# Altium schematic sheet VT6212 Chipset.SchDoc
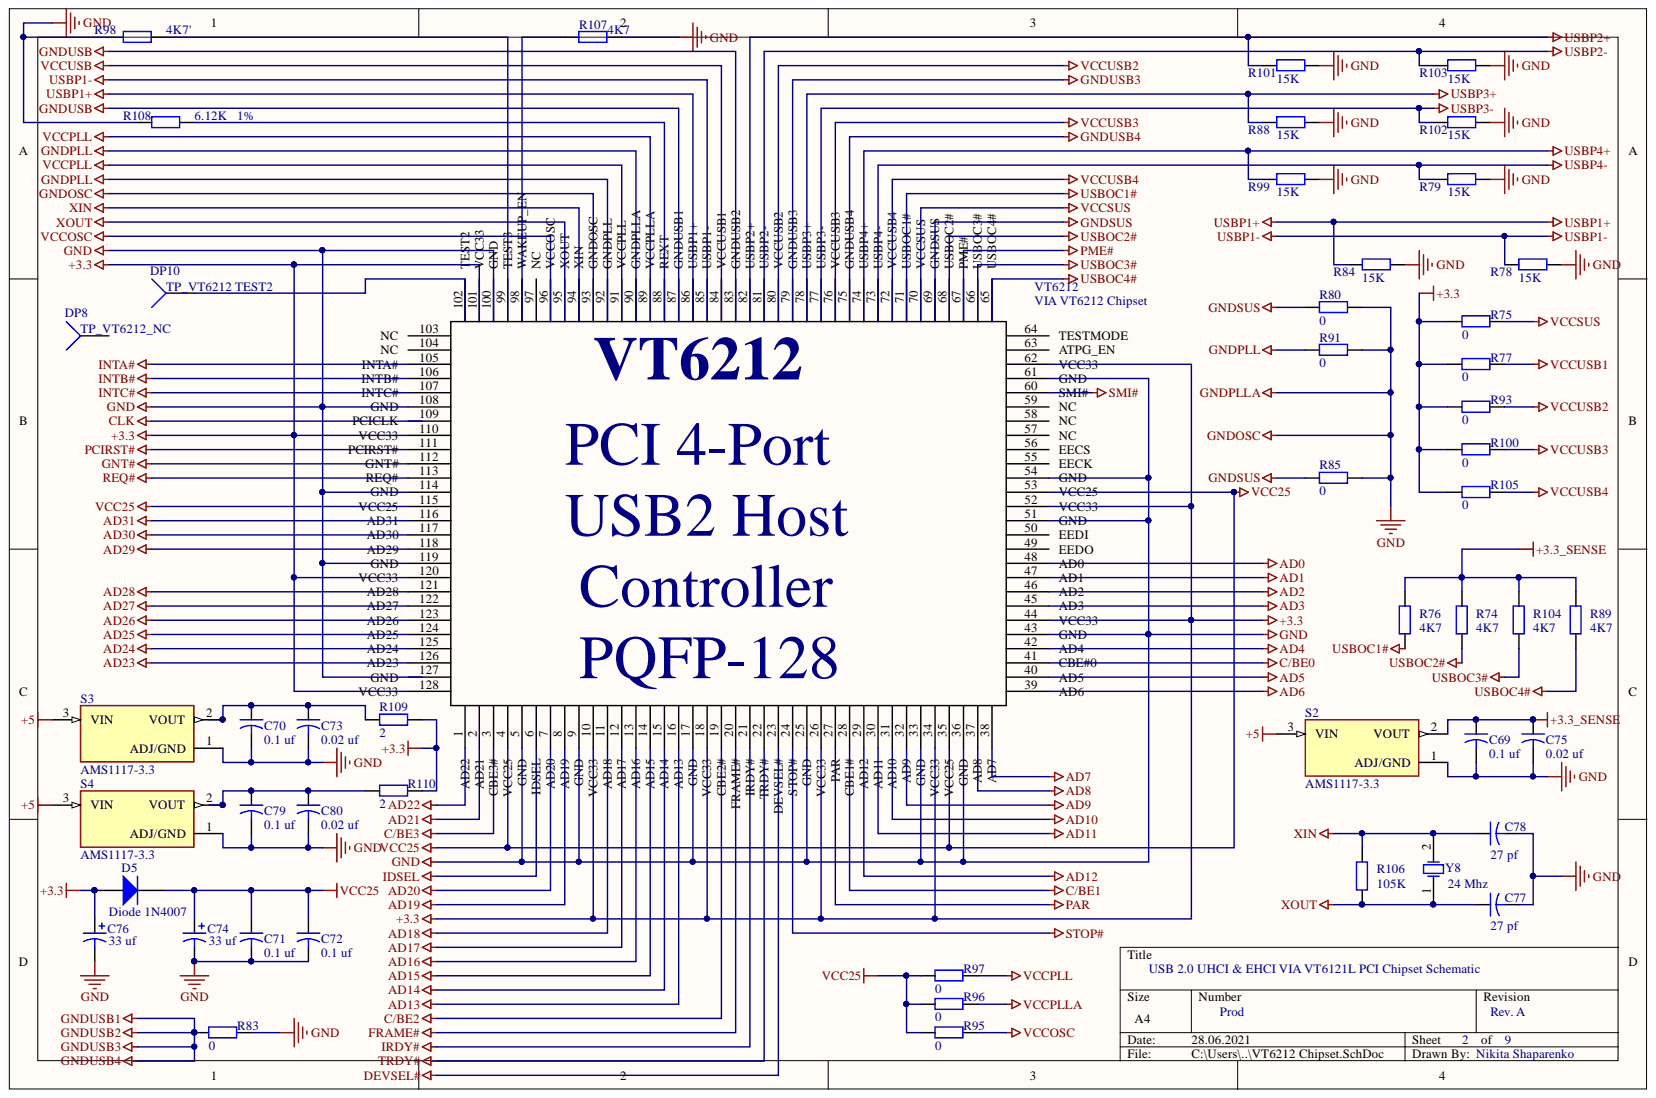
[diagram p0: VT6212 Chipset.SchDoc]

## Parts
C69  value 0.1 uf  [CAPR5-4X5]  (hidden comment: Cap2)
C70  value 0.1 uf  [CAPR5-4X5]  (hidden comment: Cap2)
C71  value 0.1 uf  [CAPR5-4X5]  (hidden comment: Cap2)
C72  value 0.1 uf  [CAPR5-4X5]  (hidden comment: Cap2)
C73  value 0.02 uf  [CAPR5-4X5]  (hidden comment: Cap2)
C74  value 33 uf  [RAD-0.2]  (hidden comment: Cap Pol1)
C75  value 0.02 uf  [CAPR5-4X5]  (hidden comment: Cap2)
C76  value 33 uf  [RAD-0.2]  (hidden comment: Cap Pol1)
C77  value 27 pf  [CAPR5-4X5]  (hidden comment: Cap2)
C78  value 27 pf  [CAPR5-4X5]  (hidden comment: Cap2)
C79  value 0.1 uf  [CAPR5-4X5]  (hidden comment: Cap2)
C80  value 0.02 uf  [CAPR5-4X5]  (hidden comment: Cap2)
D5  Diode 1N4007  [DO-41]
DP8  TP_VT6212_NC  [PIN1]
DP10  TP_VT6212 TEST2  [PIN1]
R74  value 4K7  [AXIAL-0.4]  (hidden comment: Res2)
R75  value 0  [AXIAL-0.4]  (hidden comment: Res2)
R76  value 4K7  [AXIAL-0.4]  (hidden comment: Res2)
R77  value 0  [AXIAL-0.4]  (hidden comment: Res2)
R78  value 15K  [AXIAL-0.4]  (hidden comment: Res2)
R79  value 15K  [AXIAL-0.4]  (hidden comment: Res2)
R80  value 0  [AXIAL-0.4]  (hidden comment: Res2)
R83  value 0  [AXIAL-0.4]  (hidden comment: Res2)
R84  value 15K  [AXIAL-0.4]  (hidden comment: Res2)
R85  value 0  [AXIAL-0.4]  (hidden comment: Res2)
R88  value 15K  [AXIAL-0.4]  (hidden comment: Res2)
R89  value 4K7  [AXIAL-0.4]  (hidden comment: Res2)
R91  value 0  [AXIAL-0.4]  (hidden comment: Res2)
R93  value 0  [AXIAL-0.4]  (hidden comment: Res2)
R95  value 0  [AXIAL-0.4]  (hidden comment: Res2)
R96  value 0  [AXIAL-0.4]  (hidden comment: Res2)
R97  value 0  [AXIAL-0.4]  (hidden comment: Res2)
R98  value 4K7'  [AXIAL-0.4]
R99  value 15K  [AXIAL-0.4]  (hidden comment: Res2)
R100  value 0  [AXIAL-0.4]  (hidden comment: Res2)
R101  value 15K  [AXIAL-0.4]  (hidden comment: Res2)
R102  value 15K  [AXIAL-0.4]  (hidden comment: Res2)
R103  value 15K  [AXIAL-0.4]  (hidden comment: Res2)
R104  value 4K7  [AXIAL-0.4]  (hidden comment: Res2)
R105  value 0  [AXIAL-0.4]  (hidden comment: Res2)
R106  value 105K  [AXIAL-0.4]  (hidden comment: Res2)
R107  value 4K7  [AXIAL-0.4]  (hidden comment: Res2)
R108  1%  value 6.12K  [AXIAL-0.4]
R109  value 2  [AXIAL-0.4]  (hidden comment: Res2)
R110  value 2  [AXIAL-0.4]  (hidden comment: Res2)
S2  AMS1117-3.3  [SOT229P700X180-4N]
S3  AMS1117-3.3  [SOT229P700X180-4N]
S4  AMS1117-3.3  [SOT229P700X180-4N]
VT6212  VIA VT6212 Chipset  [NXP LQFP-144 SOT486-1]
Y8  24 Mhz  [R38]

## Nets
+3.3: C76.1 D5.1 R75.2 R77.2 R93.2 R100.2 R105.2 R109.2 R110.1 VT6212.101
+3.3_SENSE: C69.1 C75.1 R74.2 R76.2 R89.2 R104.2 S2.2
+5: S2.3 S3.3 S4.3
GND: C69.2 C70.2 C71.2 C72.2 C73.2 C74.2 C75.2 C76.2 C77.2 C78.2 C79.2 C80.2 R78.1 R79.1 R80.2 R83.1 R84.1 R85.2 R88.1 R91.2 R98.1 R99.1 R101.1 R102.1 R103.1 R107.1 R108.1 S2.1 S3.1 S4.1 VT6212.93 VT6212.100
GNDPLL: R91.1 VT6212.90 VT6212.92
GNDSUS: R80.1 R85.1 VT6212.69
GNDUSB: VT6212.83 VT6212.87
GNDUSB1: R83.2 VT6212.75 VT6212.79
PME#: VT6212.67
USBOC1#: R76.1 VT6212.71
USBOC2#: R74.1 VT6212.68
USBOC3#: R104.1 VT6212.66
USBOC4#: R89.1 VT6212.65
USBP1+: R84.2 VT6212.86
USBP1-: R78.2 VT6212.85
USBP2+: R101.2 VT6212.82
USBP2-: R103.2 VT6212.81
USBP3+: R88.2 VT6212.78
USBP3-: R102.2 VT6212.77
USBP4+: R99.2 VT6212.74
USBP4-: R79.2 VT6212.73
VCC25: C71.1 C72.1 C74.1 D5.2 R95.2 R96.2 R97.2
VCCOSC: R95.1 VT6212.96
VCCPLL: R97.1 VT6212.89 VT6212.91
VCCPLLA: R96.1
VCCSUS: R75.1 VT6212.70
VCCUSB: VT6212.84
VCCUSB1: R77.1
VCCUSB2: R93.1 VT6212.80
VCCUSB3: R100.1 VT6212.76
VCCUSB4: R105.1 VT6212.72
XIN: C78.1 R106.2 VT6212.94 Y8.2
XOUT: C77.1 R106.1 VT6212.95 Y8.1
NetC70_1: C70.1 C73.1 R109.1 S3.2
NetC79_1: C79.1 C80.1 R110.2 S4.2
NetDP10_1: DP10.1 VT6212.102
NetR98_2: R98.2 VT6212.99
NetR107_2: R107.2 VT6212.98
NetR108_2: R108.2 VT6212.88
AD0:
AD1:
AD2:
AD3:
AD4:
AD5:
AD6:
AD7:
AD8:
AD9:
AD10:
AD11:
AD12:
AD13:
AD14:
AD15:
AD16:
AD17:
AD18:
AD19:
AD20:
AD21:
AD22:
AD23:
AD24:
AD25:
AD26:
AD27:
AD28:
AD29:
AD30:
AD31:
C/BE0:
C/BE1:
C/BE2:
C/BE3:
CLK:
DEVSEL#:
FRAME#:
GNT#:
IDSEL:
INTA#:
INTB#:
INTC#:
IRDY#:
PAR:
PCIRST#:
REQ#:
SMI#:
STOP#:
TRDY#:

Not connected: DP8 1; VT6212 1 2 3 4 5 6 7 8 9 10 11 12 13 14 15 16 17 18 19 20 21 22 23 24 25 26 27 28 29 30 31 32 33 34 35 36 37 38 39 40 41 42 43 44 45 46 47 48 49 50 51 52 53 54 55 56 57 58 59 60 61 62 63 64 97 103 104 105 106 107 108 109 110 111 112 113 114 115 116 117 118 119 120 121 122 123 124 125 126 127 128

## Library data of the parts
C69-C73 C75 C77-C80 = Cap2: Capacitor; Component Kind: Standard; Library Name: Miscellaneous Devices.IntLib; PackageDescription: Capacitor; 2 Leads; PackageReference: CAPR5-4X5; Published: 23-Sep-2002; Signal Integrity: Cap; Simulation: CAP; Publisher: Altium Limited
C74 C76 = Cap Pol1: Polarized Capacitor (Radial); Component Kind: Standard; LatestRevisionDate: 17-Jul-2002; LatestRevisionNote: Re-released for DXP Platform.; Library Name: Miscellaneous Devices.IntLib; PackageDescription: Polarized Capacitor (Radial); 2 Leads; PackageReference: RB7.6-15; Published: 8-Jun-2000; Signal Integrity: Cap Pol; Simulation: CAP; Publisher: Altium Limited
D5 = Diode 1N4007: 1 Amp General Purpose Rectifier; Code_JEDEC: DO-204-AL; Component Kind: Standard; ComponentLink1Description: Manufacturer Link; ComponentLink1URL: http://www.fairchildsemi.com/; ComponentLink2Description: Datasheet; ComponentLink2URL: http://www.fairchildsemi.com/ds/1N%2F1N4001.pdf; DatasheetVersion: 1998; LatestRevisionDate: 17-Jul-2002; LatestRevisionNote: Re-released for DXP Platform.; Library Name: Miscellaneous Devices.IntLib; PackageDescription: Diode, Axial; Body 5.2 x 2.7 mm (LxDia. max), Lead Dia. 0.86 mm (max); PackageReference: DO-41; PackageVersion: Aug-1999; Published: 8-Jun-2000; Signal Integrity: 1N4007; Simulation: 1N4007; Publisher: Altium Limited
DP8 DP10 = Socket: Component Kind: Standard; Description: Socket; LatestRevisionDate: 17-Jul-2002; LatestRevisionNote: Re-released for DXP Platform.; Library Name: Miscellaneous Connectors.IntLib; Signal Integrity: Connector; Publisher: Altium Limited
R74-R80 R83-R85 R88 R89 R91 R93 R95-R110 = Res2: Resistor; Component Kind: Standard; LatestRevisionDate: 17-Jul-2002; LatestRevisionNote: Re-released for DXP Platform.; Library Name: Miscellaneous Devices.IntLib; PackageDescription: Axial Device, Thru-Hole; 2 Leads; 0.4 in Pin Spacing; PackageReference: AXIAL-0.4; Published: 8-Jun-2000; Signal Integrity: Res; Simulation: RESISTOR; Publisher: Altium Limited
S2-S4 = AMS1117-3.3: Sot223/Pkg 1-Amp 3.3-Volt Low Drop Out Voltage Regulatator; MF: Advanced Monolithic Systems; Availability: Unavailable; Component Kind: Standard; Library Name: AMS1117-3.3.IntLib; MP: AMS1117-3.3; Package: None; Price: None; SnapEDA_Link: https://www.snapeda.com/parts/AMS1117-3.3/Advanced%20Monolithic%20Systems/view-part/53450/?ref=snap
VT6212 = VT6212: VT6212 PCI 4-Port USB2 Host Controller PQFP-128; Component Kind: Standard; Library Name: VIA VT6212.SchLib
Y8 = XTAL: Crystal Oscillator; Component Kind: Standard; LatestRevisionDate: 17-Jul-2002; LatestRevisionNote: Re-released for DXP Platform.; Library Name: Miscellaneous Devices.IntLib; PackageDescription: Crystal, Thru-Hole; 2 Leads; Body 3.1 x 8.2 mm (Dia.xH); PackageReference: R38; Published: 8-Jun-2000; Simulation: XTAL; Publisher: Altium Limited
